# Altium schematic sheet 08_HDMI_2.SchDoc
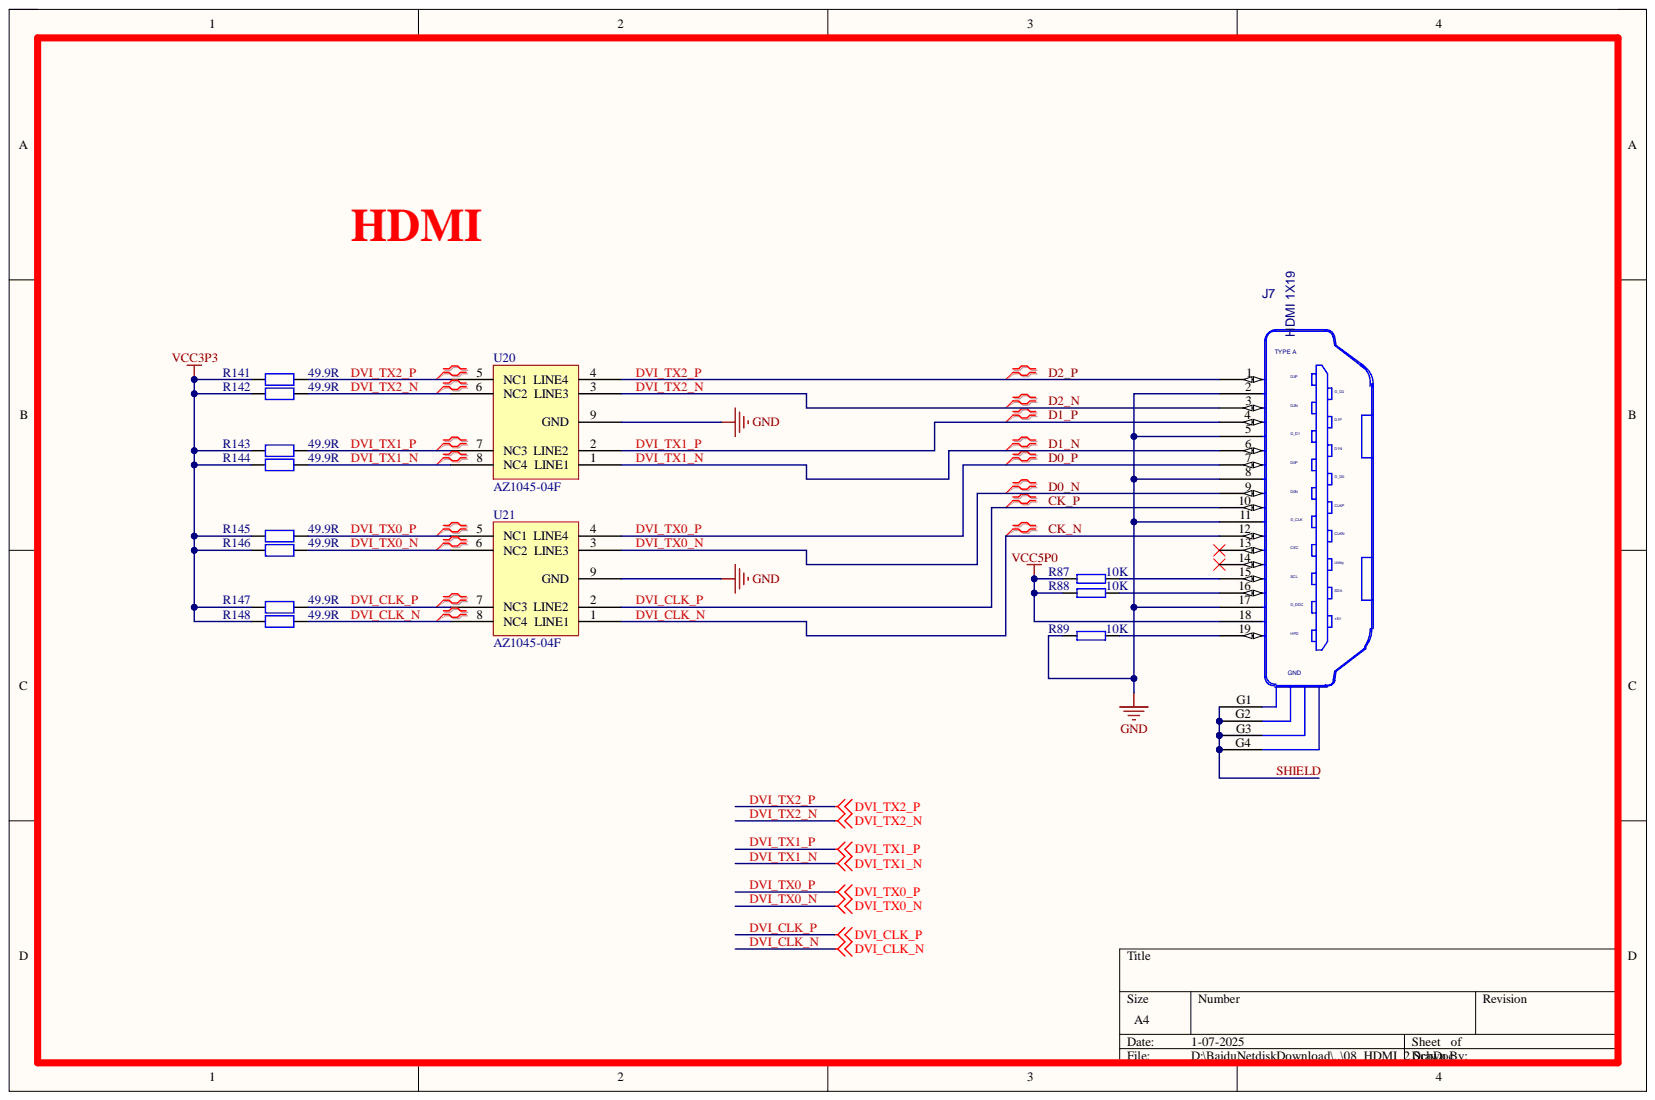
[diagram p0: 08_HDMI_2.SchDoc]

## Parts
J7  HDMI 1X19  [HDMI_A]
R87  10K  [0603R]
R88  10K  [0603R]
R89  10K  [0603R]
R141  49.9R  [0603R]
R142  49.9R  [0603R]
R143  49.9R  [0603R]
R144  49.9R  [0603R]
R145  49.9R  [0603R]
R146  49.9R  [0603R]
R147  49.9R  [0603R]
R148  49.9R  [0603R]
U20  AZ1045-04F  [SLP2710P8]
U21  AZ1045-04F  [SLP2710P8]

## Nets
GND: J7.2 J7.5 J7.8 J7.11 J7.17 R89.1 U20.9(GND) U21.9(GND)
VCC3P3: R141.1 R142.1 R143.1 R144.1 R145.1 R146.1 R147.1 R148.1
VCC5P0: J7.18 R87.1 R88.1
CK_N: J7.12 R148.2 U21.1(LINE1) U21.8(NC4)
CK_P: J7.10 R147.2 U21.2(LINE2) U21.7(NC3)
D0_N: J7.9 R146.2 U21.3(LINE3) U21.6(NC2)
D0_P: J7.7 R145.2 U21.4(LINE4) U21.5(NC1)
D1_N: J7.6 R144.2 U20.1(LINE1) U20.8(NC4)
D1_P: J7.4 R143.2 U20.2(LINE2) U20.7(NC3)
D2_N: J7.3 R142.2 U20.3(LINE3) U20.6(NC2)
D2_P: J7.1 R141.2 U20.4(LINE4) U20.5(NC1)
SHIELD: J7.G1 J7.G2 J7.G3 J7.G4
NetJ7_15: J7.15 R87.2
NetJ7_16: J7.16 R88.2
NetJ7_19: J7.19 R89.2

Not connected: J7 13 14

## Library data of the parts
J7 = ATOM010130010052_HDMI_1: CON 1X19 HDMI SMT 0.5MM 249H AU --; AGILE_PN: 211-79674; CAD_APPROVED: Yes; CLASS: IO; MFG_NAME01: SHENZHEN ATOM TECHNOLOGY CO.,LTD; MFG_PN01: HD119F-3A04202; OriginalSymbolOrigin: (-200,650); Vendor: Comtek; VPN: 1166-2C105-211; Component Kind: Standard; HEIGHT: 6.33MM; Library Name: zynq.SCHLIB; ROHS: Yes
R87-R89 = RES: Value: 10KΩ; 元件描述: 贴片电阻; 是否安装: 是; 贴片和直插: SMT; Component Kind: Standard; Library Name: GSZHSCH-V106.LIB
R141-R148 = Res2: Resistor; Component Kind: Standard; LatestRevisionDate: 17-Jul-2002; LatestRevisionNote: Re-released for DXP Platform.; PackageReference: AXIAL-0.4; Published: 8-Jun-2000; Value: 49.9R; Library Name: 元件封装库.pcblib; Publisher: Altium Limited
U20 U21 = AZ1045-04F: 元件描述: 集成电路; 是否安装: 是; 贴片和直插: SMT; Component Kind: Standard; Library Name: Schlib1.SchLib; Value: AZ1045-04F
